# Altium schematic sheet 5_Non_Volatile_Memory.SchDoc
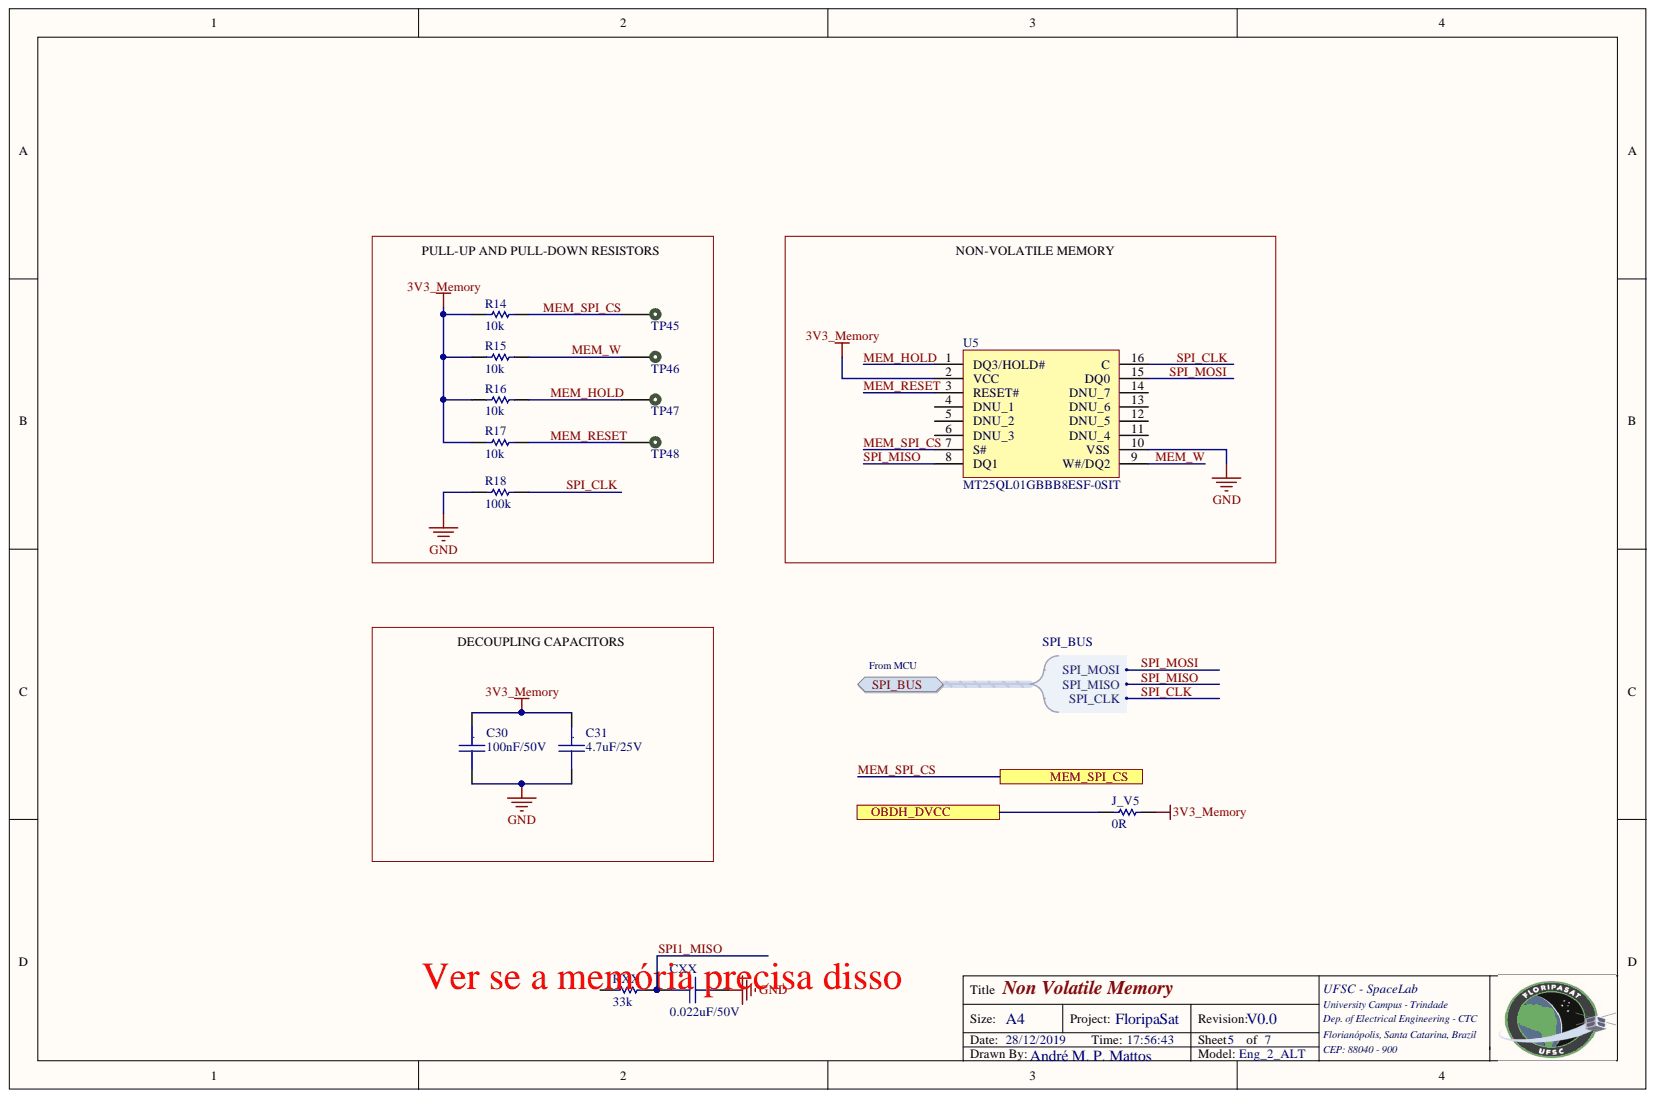
[diagram p0: 5_Non_Volatile_Memory.SchDoc]

## Parts
C30  100nF/50V  [CC0805]
C31  4.7uF/25V  [CC0805]
CXX  0.022uF/50V  [CC0805]
J_V5  0R  [0805]
R14  10k  [0805]
R15  10k  [0805]
R16  10k  [0805]
R17  10k  [0805]
R18  100k  [0805]
RXX  33k  [0805]
TP45  TEST_POINT_2  [TEST_POINT_2]  (value not drawn)  (no BOM)
TP46  TEST_POINT_2  [TEST_POINT_2]  (value not drawn)  (no BOM)
TP47  TEST_POINT_2  [TEST_POINT_2]  (value not drawn)  (no BOM)
TP48  TEST_POINT_2  [TEST_POINT_2]  (value not drawn)  (no BOM)
U5  MT25QL01GBBB8ESF-0SIT  [SOIC127P1032X265-16N]

## Ports
MEM_SPI_CS, OBDH_DVCC, SPI_BUS, SPI_BUS.SPI_CLK, SPI_BUS.SPI_MISO, SPI_BUS.SPI_MOSI

## Nets
3V3_Memory: C30.1 C31.1 J_V5.1 R14.2 R15.2 R16.2 R17.2 U5.2(VCC)
GND: C30.2 C31.2 CXX.2 R18.2 U5.10(VSS)
MEM_HOLD: R16.1 TP47.1 U5.1(DQ3/HOLD#)
MEM_RESET: R17.1 TP48.1 U5.3(RESET#)
MEM_SPI_CS: R14.1 TP45.1 U5.7(S#) ; port MEM_SPI_CS
MEM_W: R15.1 TP46.1 U5.9(W#/DQ2)
OBDH_DVCC: J_V5.2 ; port OBDH_DVCC
SPI1_MISO: CXX.1 RXX.1
SPI_CLK: R18.1 U5.16(C) ; port SPI_BUS
SPI_MISO: U5.8(DQ1) ; port SPI_BUS
SPI_MOSI: U5.15(DQ0) ; port SPI_BUS

Not connected: RXX 2; U5 4(DNU_1) 5(DNU_2) 6(DNU_3) 11(DNU_4) 12(DNU_5) 13(DNU_6) 14(DNU_7)

## Library data of the parts
C30 = Cap_Cer_X7R_100nF_50V_0603: CAP CER 100nF 50V 0603 10%; Partnumber: C0603C104K5RAC3121; Component Kind: Standard; NCM: 8532.24.10; Library Name: OBDH_Eng_Model_2_ALT.SCHLIB
C31 = Cap_Cer_X7R_4.7uF_25V_0805: CAP CER 4.7UF 25V 10% X7R 0805; Partnumber: C0805C475K3RACAUTO; Component Kind: Standard; NCM: 8532.24.10; Library Name: OBDH_Eng_Model_2_ALT.SCHLIB
CXX = Cap_Cer_X7R_0.022uF_50V_0805: CAP CER 0.022UF 50V 5% X7R 0805; Partnumber: CGA4J2C0G1H223J125AA; Component Kind: Standard; NCM: 8532.24.10; Library Name: OBDH_Eng_Model_2_ALT.SCHLIB
J_V5 = R0R_JUMPER_1/16W_0805: RES 0R OHM 1/16W JUMPER 0805; Partnumber: CRCW08050000Z0EA; Component Kind: Standard; NCM: 8533.21.20; Library Name: OBDH_Eng_Model_2_ALT.SCHLIB
R14-R17 = R10k_1%_1/8W_0805: RES 10K OHM 1/8W 1%  0805; Partnumber: CRCW080510K0FKEA; Component Kind: Standard; NCM: 8533.21.20; Library Name: OBDH_Eng_Model_2_ALT.SCHLIB
R18 = R100k_1%_1/8W_0805: RES 100K OHM 1/8W 1% 0805; Partnumber: AC0805FR-13100KL; Component Kind: Standard; NCM: 8533.21.20; Library Name: OBDH_Eng_Model_2_ALT.SCHLIB
RXX = R33k_1%_1/10W_0805: RES 33K OHM 1/10W 1% 0805; Partnumber: RC0805FR-0733KL; Component Kind: Standard; NCM: 8533.21.20; Library Name: OBDH_Eng_Model_2_ALT.SCHLIB
TP45-TP48 = TEST_POINT_2: Test point; Library Name: OBDH_Eng_Model_2_ALT.SCHLIB
U5 = MT25QL01GBBB8ESF-0SIT: IC FLASH 1GBIT 108MHZ 16SOIC; Manufacturer_Name: Micron; Geometry.Height: 2.65mm; Component Kind: Standard; Datasheet Link: https://www.micron.com/~/media/documents/products/data-sheet/nor-flash/serial-nor/mt25q/die-rev-b/mt25q_qlkt_l_01g_bbb_0.pdf; Manufacturer_Part_Number: MT25QL01GBBB8ESF-0SIT; Mouser Part Number: 340-278808-TRAY; Mouser Price/Stock: https://www.mouser.com/Search/Refine.aspx?Keyword=340-278808-TRAY; Library Name: OBDH_Eng_Model_2_ALT.SCHLIB
